# Altium schematic sheet EasyGate1541 DIP-40.SchDoc("EasyGate1541 DIP-40")
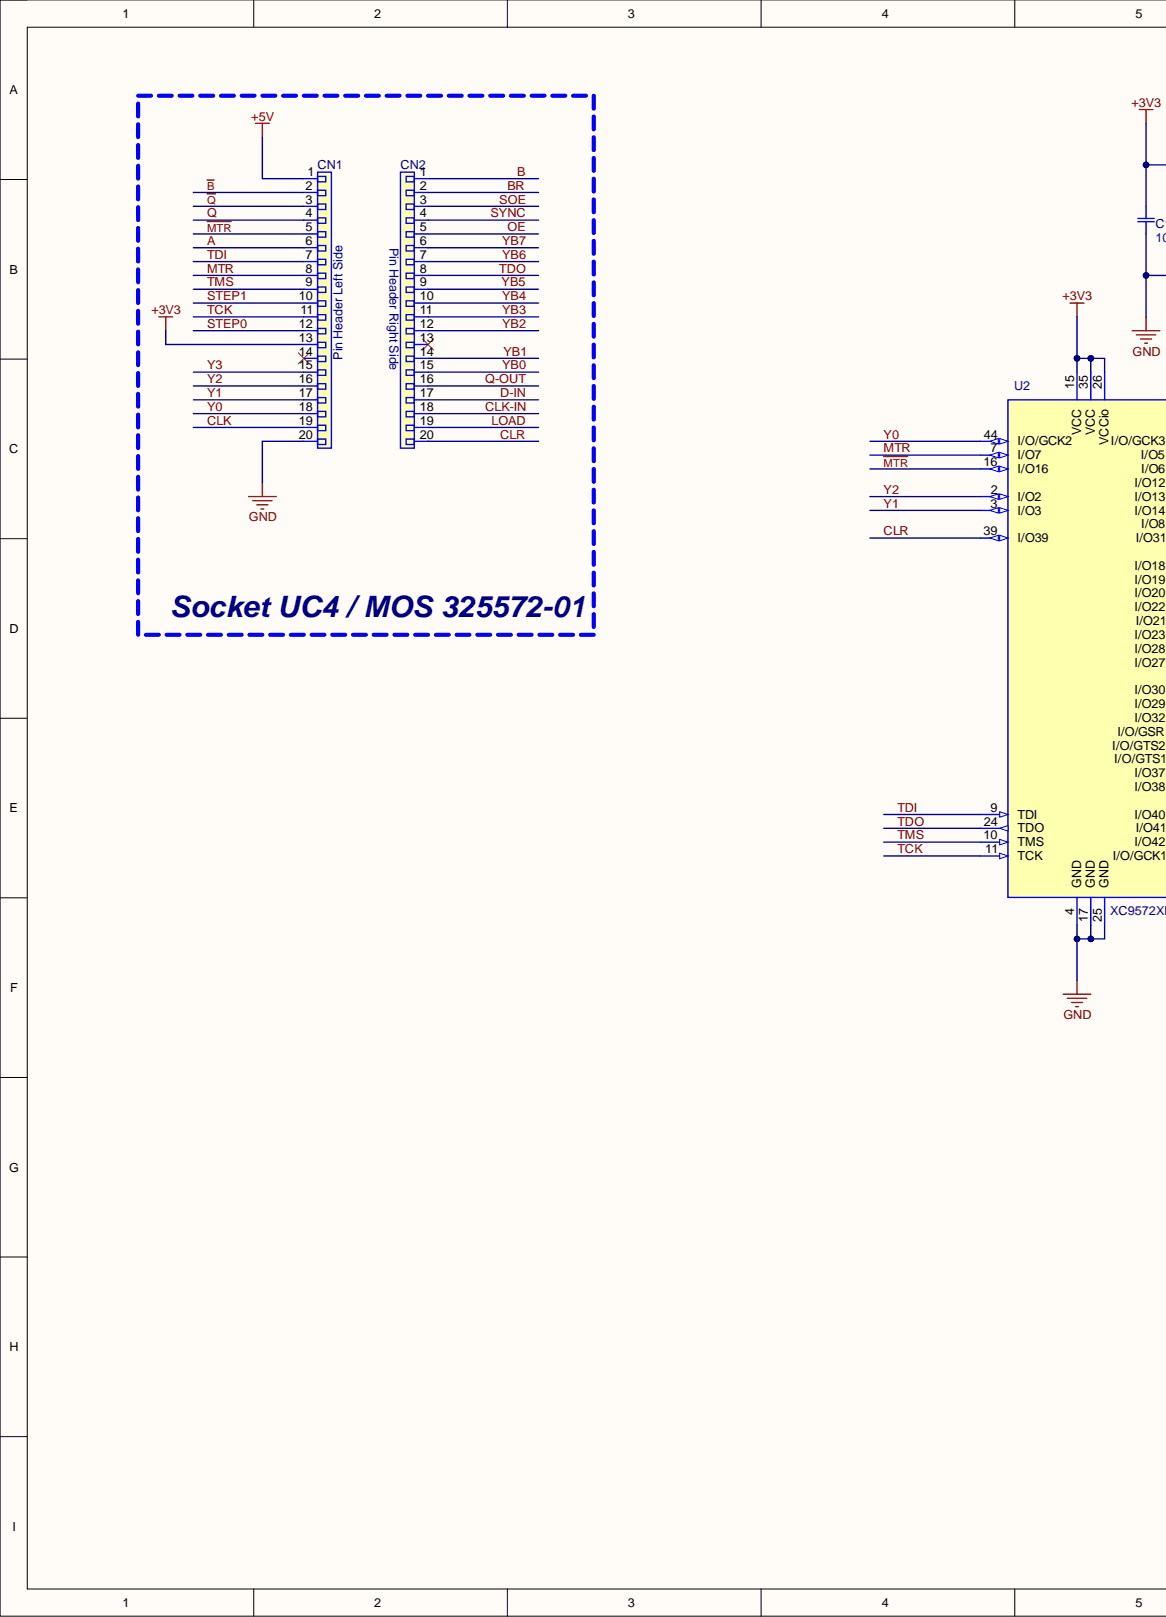
[diagram p0: EasyGate1541 DIP-40.SchDoc, left]
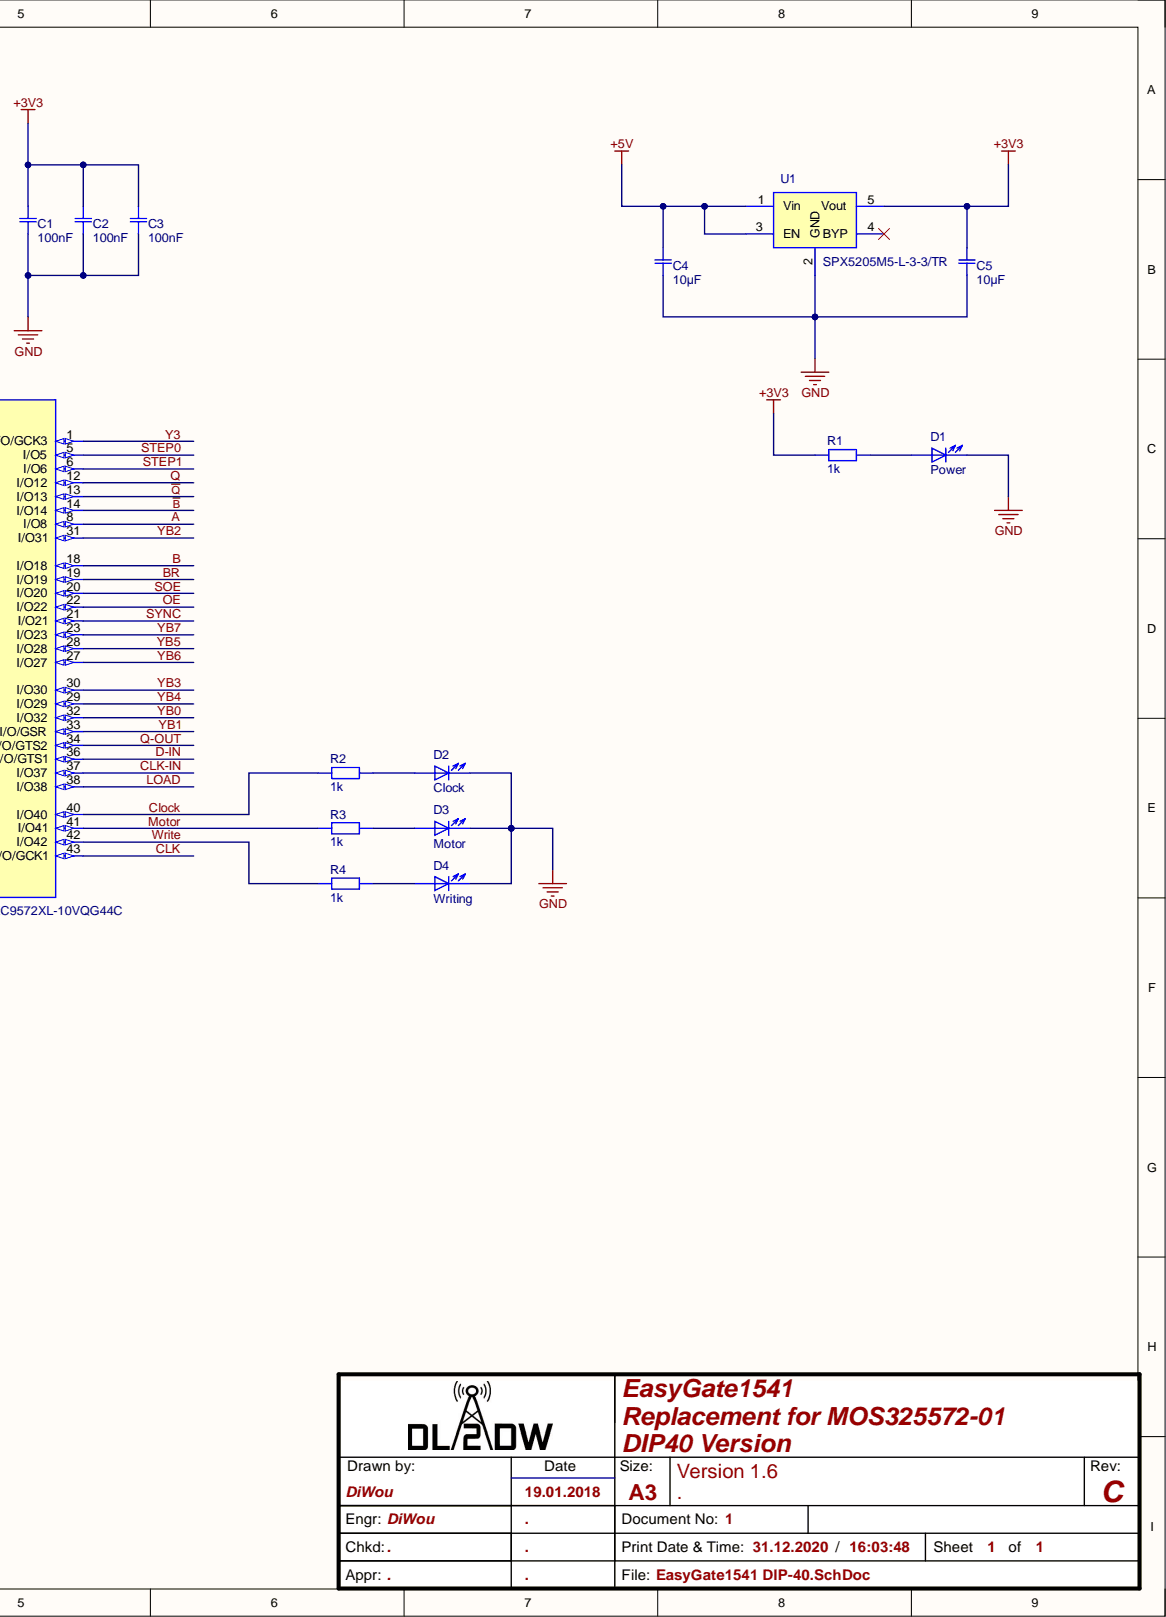
[diagram p1: EasyGate1541 DIP-40.SchDoc, right]

## Parts
C1  100nF  [CAP 100nF SMD 0603 C0603C104J3RACTU]
C2  100nF  [CAP 100nF SMD 0603 C0603C104J3RACTU]
C3  100nF  [CAP 100nF SMD 0603 C0603C104J3RACTU]
C4  10µF  [Kemet C0805CxxxK8RACTU Series H=1.4mm]
C5  10µF  [Kemet C0805CxxxK8RACTU Series H=1.4mm]
CN1  Pin Header Left Side  [Pin Header 01x20 2.54mm precision Horizontal Male]
CN2  Pin Header Right Side  [Pin Header 01x20 2.54mm precision Horizontal Male]
D1  Power  [LED green Gullwing Everlight 25-21/GHC-YSU/2A]
D2  Clock  [LED blue Gullwing Everlight 25-21/BHC-XR2S1/2A]
D3  Motor  [LED white Gullwing Everlight 25-21/T1D-ANQHY/2A]
D4  Writing  [LED red Gullwing Everlight 25-21SURC/S530-A4/TR8]
R1  1k  [1k Ohm R 0603 SMD]
R2  1k  [1k Ohm R 0603 SMD]
R3  1k  [1k Ohm R 0603 SMD]
R4  1k  [1k Ohm R 0603 SMD]
U1  SPX5205M5-L-3-3/TR  [SOT-23-5]
U2  XC9572XL-10VQG44C  [XC9572XL-10VQG44C]

## Nets
+3V3: C1.2 C2.2 C3.2 C5.2 CN1.13 R1.1 U1.5(Vout) U2.15(VCC) U2.26(VCCio) U2.35(VCC)
+5V: C4.2 CN1.1 U1.1(Vin) U1.3(EN)
GND: C1.1 C2.1 C3.1 C4.1 C5.1 CN1.20 D1.1 D2.1 D3.1 D4.1 U1.2(GND) U2.4(GND) U2.17(GND) U2.25(GND)
A: CN1.6 U2.8(I/O8)
B: CN2.1 U2.18(I/O18)
~{B}: CN1.2 U2.14(I/O14)
BR: CN2.2 U2.19(I/O19)
CLK: CN1.19 U2.43(I/O/GCK1)
CLK-IN: CN2.18 U2.37(I/O37)
Clock: R2.1 U2.40(I/O40)
CLR: CN2.20 U2.39(I/O39)
D-IN: CN2.17 U2.36(I/O/GTS1)
LOAD: CN2.19 U2.38(I/O38)
~{MTR}: CN1.5 U2.16(I/O16)
Motor: R3.1 U2.41(I/O41)
MTR: CN1.8 U2.7(I/O7)
OE: CN2.5 U2.22(I/O22)
Q: CN1.4 U2.12(I/O12)
Q-OUT: CN2.16 U2.34(I/O/GTS2)
~{Q}: CN1.3 U2.13(I/O13)
SOE: CN2.3 U2.20(I/O20)
STEP0: CN1.12 U2.5(I/O5)
STEP1: CN1.10 U2.6(I/O6)
SYNC: CN2.4 U2.21(I/O21)
TCK: CN1.11 U2.11(TCK)
TDI: CN1.7 U2.9(TDI)
TDO: CN2.8 U2.24(TDO)
TMS: CN1.9 U2.10(TMS)
Write: R4.1 U2.42(I/O42)
Y0: CN1.18 U2.44(I/O/GCK2)
Y1: CN1.17 U2.3(I/O3)
Y2: CN1.16 U2.2(I/O2)
Y3: CN1.15 U2.1(I/O/GCK3)
YB0: CN2.15 U2.32(I/O32)
YB1: CN2.14 U2.33(I/O/GSR)
YB2: CN2.12 U2.31(I/O31)
YB3: CN2.11 U2.30(I/O30)
YB4: CN2.10 U2.29(I/O29)
YB5: CN2.9 U2.28(I/O28)
YB6: CN2.7 U2.27(I/O27)
YB7: CN2.6 U2.23(I/O23)
NetD1_2: D1.2 R1.2
NetD2_2: D2.2 R2.2
NetD3_2: D3.2 R3.2
NetD4_2: D4.2 R4.2

Not connected: CN1 14; CN2 13; U1 4(BYP)

## Library data of the parts
C1-C3 = CMP-Capacitors-Ceramic_Capacitors-00012-1: KEMET         C0603C104J3RACTU             SMD Multilayer Ceramic Capacitor, 0603 [1608 Metric], 0.1 F, 25 V,  5%, X7R, C Series; Voltage: 25V; Capacitance: 100nF; Case Code (Imperial): 0603; Case Code (Metric): 1608; Case/Package: 0603; Component Kind: Standard; Contact Plating: Tin,Matte; Depth: 0.8mm; Dielectric: X7R; Dielectric Material: Ceramic; Height: 0.8mm; Lead Pitch: 0.7mm; Length: 1.6mm; Library Name: PCB-Tech Cloud; Max Operating Temperature: 125°C; Min Operating Temperature: -55°C; Mount: Surface Mount,PCB; Number of Pins: 2; Package Quantity: 4000; Packaging: Tape and Reel; Radiation Hardening: No; REACH SVHC: No SVHC; Termination: SMD/SMT; Thickness: 0.034inch; Tolerance: 5%; Voltage Rating: 25V; Voltage Rating (DC): 25V; Width: 0.031inch
C4 C5 = CMP-Capacitors-Ceramic_Capacitors-00016-2: KEMET - C0805C106K8RACTU - CAP, 10µF, 10V, 10%, X7R, 0805; Capacitance: 10uF; Dissipation Factor: 7.5%; Case Code (Imperial): 0805; Case Code (Metric): 2012; Case/Package: 0805; Component Kind: Standard; Depth: 1.25mm; Dielectric: X7R; Dielectric Material: Ceramic; Height: 1.25mm; Length: 2mm; Library Name: PCB-Tech Cloud; Max Operating Temperature: 125°C; Min Operating Temperature: -55°C; Mount: Surface Mount,PCB; Package Quantity: 1; Packaging: Tape and Reel; REACH SVHC: No SVHC; Termination: SMD/SMT; Thickness: 0.055inch; Tolerance: 10%; Voltage: 10V; Voltage Rating: 10V; Voltage Rating (DC): 10V; Width: 1.2mm
CN1 CN2 = CMP-Connectors-Male_PIN_Headers-00031-2: Conn Header Vert 20POS 2MM; Connector Type: Male Pin; Number of Positions: 20; Pitch: 0.079inch; Color: Black; Component Kind: Standard; Contact Mating Length: 0.141inch; Contact Plating: Gold; Library Name: PCB-Tech Cloud; Mount: Through Hole; Number of Rows: 1; Package Quantity: 250; Packaging: Bulk; Termination: Solder
D1 = CMP-LEDs-00010-1: LED Uni-Color Green 518nm 2-Pin Chip LED T/R; Dominant Wavelength: 525nm; Forward Current: 20mA; Forward Voltage: 3.5V; Lens Style: Diffused,Colorless,Circular; Number of LEDs: 1; Viewing Angle: 60°; Color: Green; Component Kind: Standard; Library Name: PCB-Tech Cloud; Mount: Surface Mount; Number of Pins: 2; Packaging: Tape and Reel; Radiation Hardening: No
D2 = CMP-LEDs-00012-1: LED Uni-Color Blue 468nm Chip LED 2-Pin SMD T/R; Forward Current: 20mA; Component Kind: Standard; Library Name: PCB-Tech Cloud
D3 = CMP-LEDs-00011-1: Standard LEDs - SMD SMD LED; Color: White; Forward Current: 25mA; Forward Voltage: 2.92V; Lens Color: Yellow; Lens Style: Diffused,Colored,Circular; Lens Transparency: Diffused; Luminous Intensity: 70mcd; Number of Elements: 1; Power Dissipation: 95mW; Reverse Voltage: 5V; Test Current: 5mA; Viewing Angle: 130°; Case/Package: SMD/SMT; Component Kind: Standard; Depth: 1.6mm; Height: 1.1mm; Length: 3.2mm; Library Name: PCB-Tech Cloud; Max Operating Temperature: 85°C; Min Operating Temperature: -40°C; Mount: Surface Mount; Number of LEDs: 1; Number of Pins: 2; Package Quantity: 2000; Packaging: Tape and Reel; Radiation Hardening: No; Width: 1.6mm
D4 = CMP-LEDs-00009-3: SMD LED red 2.7. . .3.15 V Bottom View, 25-21SURC/S530-A4/TR8, Everlight Electronics; Forward Current: 20mA; Component Kind: Standard; Library Name: PCB-Tech Cloud
R1-R4 = CMP-Resistors-Yageo_SMD_060300002-1: RES SMD 1K OHM 1% 1/10W 0603; Power Rating: 100mW; Resistance: 1kR; Temperature Coefficient: 100ppm/°C; Voltage Rating: 50V; Case Code (Imperial): 0603; Case Code (Metric): 1608; Case/Package: 0603; Component Kind: Standard; Composition: Thick Film; Contact Plating: Tin,Matte; Depth: 0.8mm; Height: 0.45mm; Lead Free: Lead Free; Length: 1.6mm; Library Name: PCB-Tech Cloud; Max Operating Temperature: 155°C; Military Standard: Not; Min Operating Temperature: -55°C; Packaging: Tape and Reel; Radiation Hardening: No; REACH SVHC: No SVHC; Termination: SMD/SMT; Tolerance: 1%
U1 = CMP-ICs-Power_Supply-00002-2: LDO Regulator Pos 3.3V 0.15A 5-Pin SOT-23 T/R; Accuracy: 1%; Dropout Voltage: 210mV; Max Input Voltage: 16V; Max Output Current: 150mA; Min Input Voltage: 2.5V; Number of Outputs: 1; Output Type: Fixed; Polarity: Positive; Quiescent Current: 1uA; Case/Package: SOT-23; Component Kind: Standard; Height: 1.15mm; Lead Free: Lead Free; Length: 2.9mm; Library Name: PCB-Tech Cloud; Max Operating Temperature: 125°C; Min Operating Temperature: -40°C; Min Output Voltage: 3.3V; Mount: Surface Mount; Number of Pins: 5; Number of Regulators: 1; Output Current: 150mA; Output Voltage: 3.3V; Packaging: Tape and Reel; Radiation Hardening: No; Width: 1.6mm
U2 = CMP-ICs-CPLD_FPGA-00003-1: XILINX - XC9572XL-10VQG44C. - CPLD, 72 MACROCELL, 3.3V, 44VQFP; Frequency: 178MHz; Max Frequency: 100MHz; Max Supply Voltage: 3.6V; Memory Type: FLASH; Min Supply Voltage: 3V; Number of Gates: 1600; Number of I/Os: 34; Number of Logic Blocks (LABs): 4; Number of Macrocells: 72; Propagation Delay: 10ns; Speed Grade: 10; Case/Package: TQFP; Component Kind: Standard; Lead Free: Lead Free; Library Name: PCB-Tech Cloud; Max Operating Temperature: 70°C; Min Operating Temperature: 0°C; Mount: Surface Mount; Number of Logic Elements/Cells: 4; Number of Pins: 44; Operating Supply Voltage: 3.3V; Package Quantity: 160; Packaging: Tray; Radiation Hardening: No; REACH SVHC: No SVHC; Termination: SMD/SMT; Turn-On Delay Time: 10ns
